# Altium schematic sheet mpu9250.SchDoc
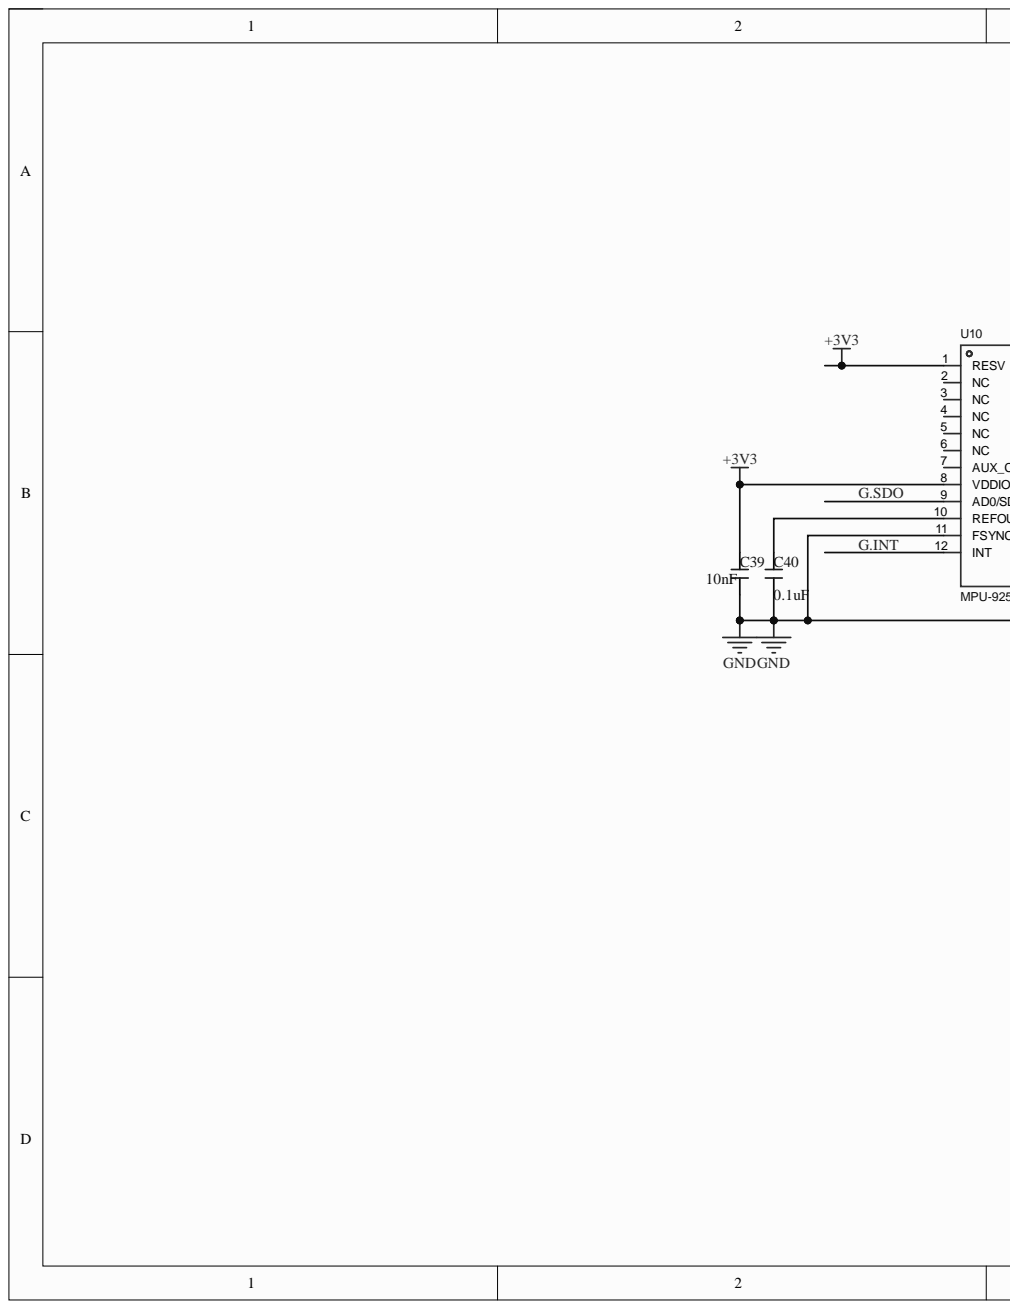
[diagram p0: mpu9250.SchDoc, left]
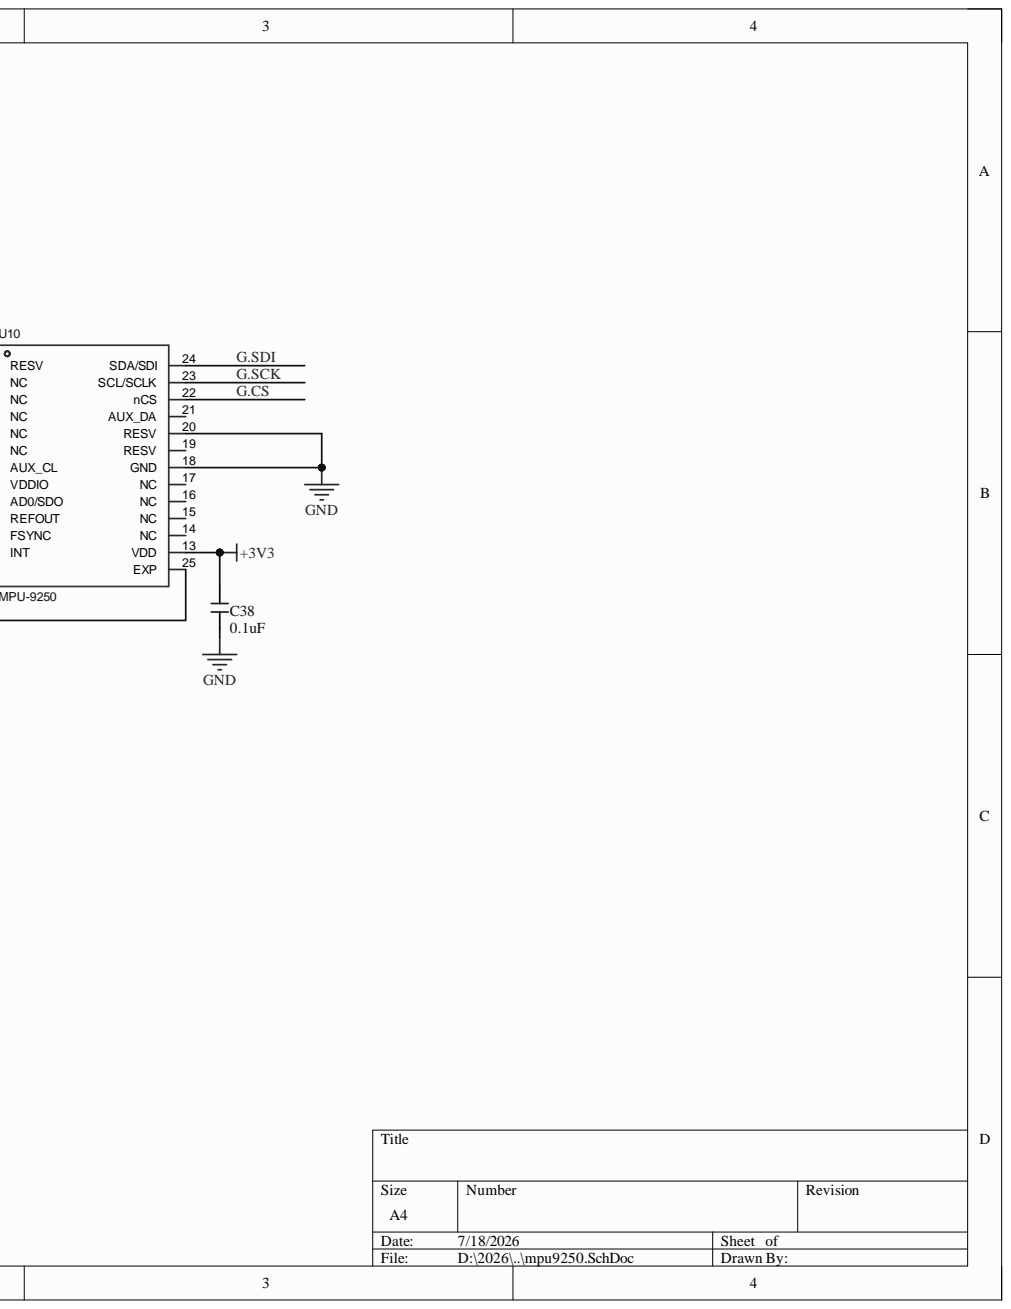
[diagram p1: mpu9250.SchDoc, right]

## Parts
C38  0.1uF  [C 0603_L]
C39  10nF  [C 0603_L]
C40  0.1uF  [C 0603_L]
U10  MPU-9250  [QFN-24_L3.0-W3.0-P0.40-BL-EP]

## Nets
+3V3: C38.1 C39.1 U10.1(RESV) U10.8(VDDIO) U10.13(VDD)
GND: C38.2 C39.2 C40.2 U10.11(FSYNC) U10.18(GND) U10.20(RESV) U10.25(EXP)
G.CS: U10.22(nCS)
G.INT: U10.12(INT)
G.SCK: U10.23(SCL/SCLK)
G.SDI: U10.24(SDA/SDI)
G.SDO: U10.9(AD0/SDO)
NetC40_1: C40.1 U10.10(REFOUT)

Not connected: U10 2(NC) 3(NC) 4(NC) 5(NC) 6(NC) 7(AUX_CL) 14(NC) 15(NC) 16(NC) 17(NC) 19(RESV) 21(AUX_DA)

## Library data of the parts
C38-C40 = C: 贴片电容; Component Kind: Standard; Library Name: GS_SchLib.SchLib
U10 = MPU-9250: 传感器类型:IMU(加速度计+陀螺仪);接口类型:I2C；SPI;; Manufacturer: TDK InvenSense(应美盛); Acceleration Measurement Range (MAX): ±16g; Accelerometer Sensing Axis: X,Y,Z; AddInBom: yes; Gyroscope Measurement Range (MAX): ±2000dps; ModelId: b6102d6ded464b998bbf3859d0a01637聨0819f05c4eef4c71ace90d822a990e87; ModelName: QFN-24_L3.0-W3.0-H0.9-P0.40-BL-EP; ModelTransform: 118.11,118.11,0,90,0,0,0,0,0; Standby Current: 8uA; Voltage - Supply: 2.4V~3.6V; Component Kind: Standard; ConvertToPcb: yes; Datasheet: https://item.szlcsc.com/datasheet/MPU-9250/72567.html; Device: MPU-9250; Features: 运动事件检测; FootprintName: QFN-24_L3.0-W3.0-P0.40-BL-EP; Gyroscope Sensing Axis: X,Y,Z; Interface: I2C；SPI; JLCPCB Part Class: Extended Part; LCSC Part Name: 停产 9轴运动跟踪处理器; ManufacturerPart: MPU-9250; Operating Temperature: -40℃~+85℃; Sensor Type: IMU(加速度计+陀螺仪); Supplier: LCSC; Supplier Footprint: QFN-24-EP(3x3); SupplierPart: C71459; Symbol: MPU-9250
